# Altium schematic sheet ESP32_board.SchDoc(ESP32_board)
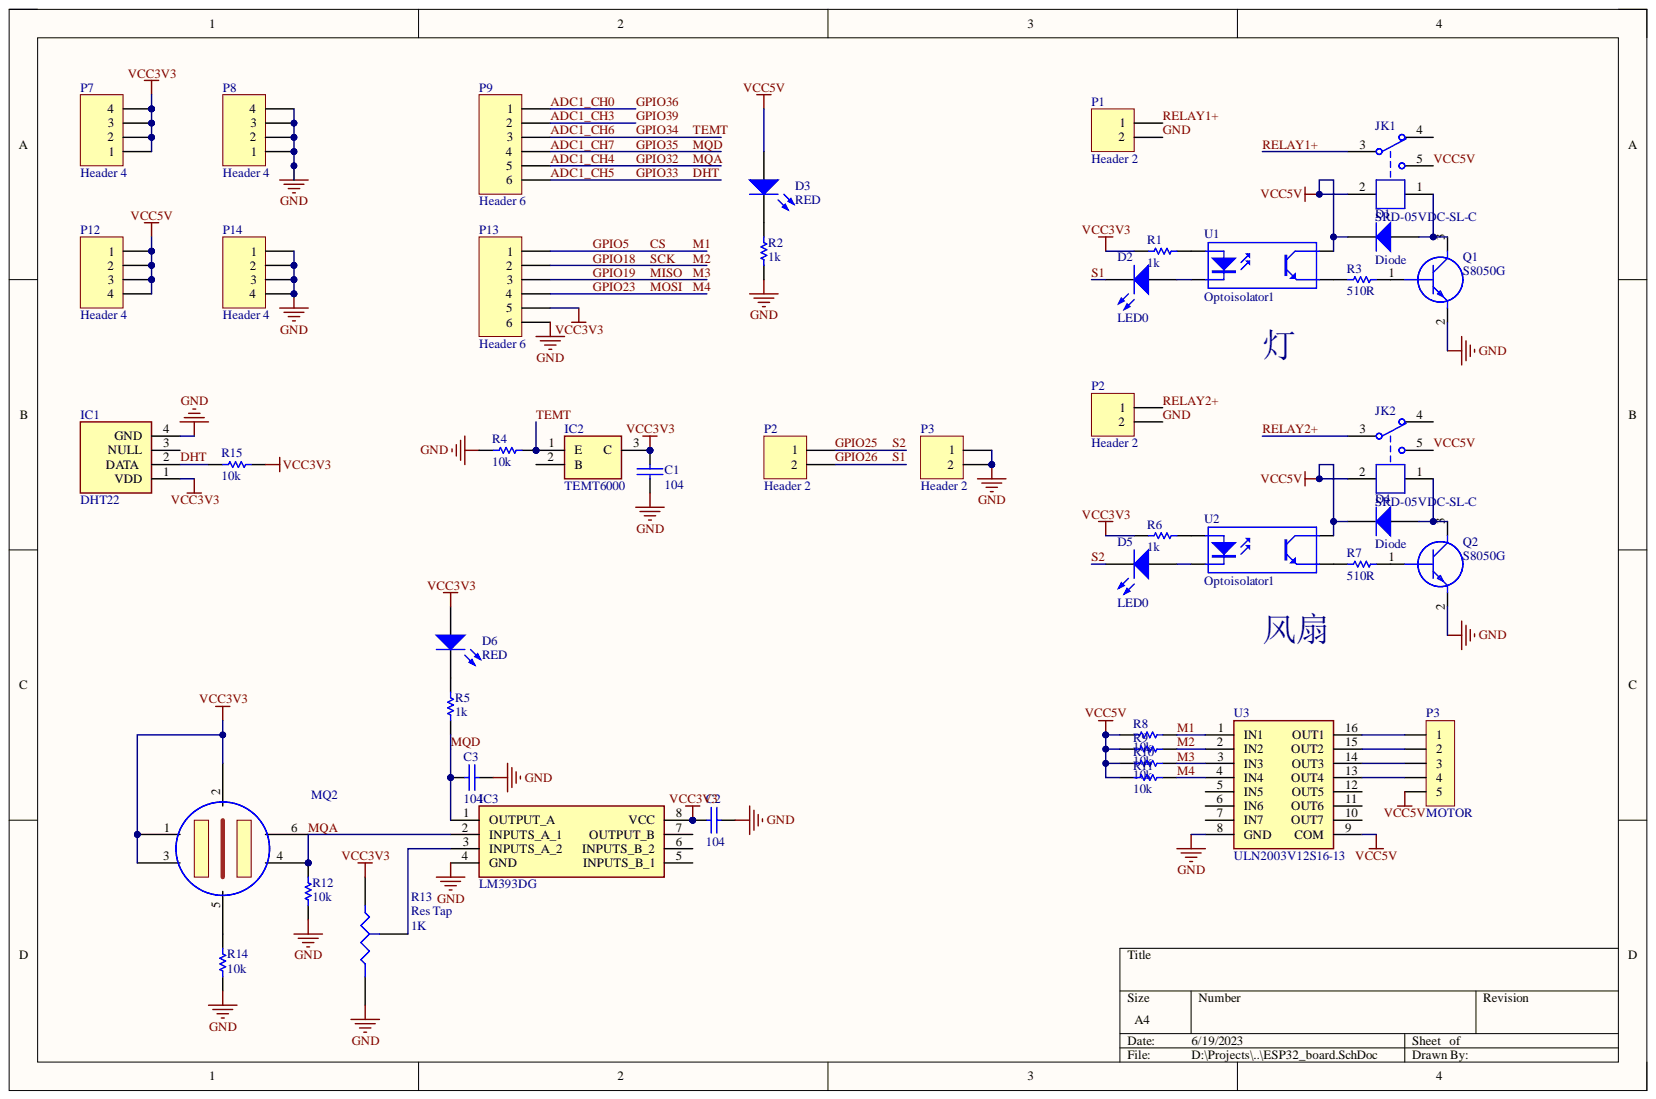
[diagram p0: ESP32_board.SchDoc]

## Parts
C1  104  [C0603_M]
C2  104  [C0603_M]
C3  104  [C0603_M]
D1  Diode  [MINI_MELF (LL34)]
D2  LED0  [LED0805_B]
D3  RED  [LED0805_B]
D4  Diode  [MINI_MELF (LL34)]
D5  LED0  [LED0805_B]
D6  RED  [LED0805_B]
IC1  DHT22  [DHT22]
IC2  TEMT6000  [TEMT6000]
IC3  LM393DG  [LM393]
JK1  SRD-05VDC-SL-C  [SRD-C_B]
JK2  SRD-05VDC-SL-C  [SRD-C_B]
MQ2  MQ  [QM-2]
P1  Header 2  [KF128-3.81-2P]
P2  Header 2  [HDR2.54-LI-2P]
P2  Header 2  [KF128-3.81-2P]
P3  Header 2  [HDR2.54-LI-2P]
P3  MOTOR  [XH2.54-LI-5P]
P7  Header 4  [HDR2.54-LI-4P]
P8  Header 4  [HDR2.54-LI-4P]
P9  Header 6  [HDR2.54-LI-6P]
P12  Header 4  [HDR2.54-LI-4P]
P13  Header 6  [HDR2.54-LI-6P]
P14  Header 4  [HDR2.54-LI-4P]
Q1  S8050G  [8050]
Q2  S8050G  [8050]
R1  value 1k  [R0603_M]  (hidden comment: Res1)
R2  value 1k  [R0603_M]  (hidden comment: Res1)
R3  value 510R  [R0603_M]  (hidden comment: Res1)
R4  value 10k  [R0603_M]  (hidden comment: Res1)
R5  value 1k  [R0603_M]  (hidden comment: Res1)
R6  value 1k  [R0603_M]  (hidden comment: Res1)
R7  value 510R  [R0603_M]  (hidden comment: Res1)
R8  value 10k  [R0603_M]  (hidden comment: Res1)
R9  value 10k  [R0603_M]  (hidden comment: Res1)
R10  value 10k  [R0603_M]  (hidden comment: Res1)
R11  value 10k  [R0603_M]  (hidden comment: Res1)
R12  value 10k  [R0603_M]  (hidden comment: Res1)
R13  Res Tap  value 1K  [RM065]
R14  value 10k  [R0603_M]  (hidden comment: Res1)
R15  value 10k  [R0603_M]  (hidden comment: Res1)
U1  Optoisolator1  [SOP4-W2.54_N]
U2  Optoisolator1  [SOP4-W2.54_N]
U3  ULN2003V12S16-13  [ULN2003]

## Nets
VCC3V3: C1.2 C2.2 D6.1 IC1.1(VDD) IC2.3 IC3.8(VCC) MQ2.1 MQ2.2 MQ2.3 P7.1 P7.2 P7.3 P7.4 P13.5 R1.2 R6.2 R13.1 R15.2
ADC1_CH0: P9.1
ADC1_CH3: P9.2
ADC1_CH4: IC3.2(INPUTS_A_1) MQ2.4 P9.5 R12.1
ADC1_CH5: IC1.2(DATA) P9.6 R15.1
ADC1_CH6: IC2.1 P9.3 R4.1
ADC1_CH7: C3.1 IC3.1(OUTPUT_A) P9.4 R5.1
CS: P13.1 R8.1 U3.1(IN1)
GND: C1.1 C2.1 C3.2 IC1.4(GND) IC3.4(GND) P1.2 P2.2 P3.1 P3.2 P8.1 P8.2 P8.3 P8.4 P13.6 P14.1 P14.2 P14.3 P14.4 Q1.2 Q2.2 R2.1 R4.2 R12.2 R13.2 R14.2 U3.8(GND)
GPIO18: P13.2 R9.1 U3.2(IN2)
GPIO19: P13.3 R10.1 U3.3(IN3)
GPIO23: P13.4 R11.1 U3.4(IN4)
GPIO25: D5.2 P2.1
GPIO26: D2.2 P2.2
RELAY1+: JK1.3 P1.1
RELAY2+: JK2.3 P2.1
VCC5V: D1.2 D3.1 D4.2 JK1.2 JK1.5 JK2.2 JK2.5 P3.5 P12.1 P12.2 P12.3 P12.4 R8.2 R9.2 R10.2 R11.2 U1.4 U2.4 U3.9(COM)
NetD1_1: D1.1 JK1.1 Q1.3
NetD2_1: D2.1 U1.2
NetD3_2: D3.2 R2.2
NetD4_1: D4.1 JK2.1 Q2.3
NetD5_1: D5.1 U2.2
NetD6_2: D6.2 R5.2
NetIC3_3: IC3.3(INPUTS_A_2) R13.3
NetMQ2_5: MQ2.5 R14.1
NetP3_1: P3.1 U3.16(OUT1)
NetP3_2: P3.2 U3.15(OUT2)
NetP3_3: P3.3 U3.14(OUT3)
NetP3_4: P3.4 U3.13(OUT4)
NetQ1_1: Q1.1 R3.1
NetQ2_1: Q2.1 R7.1
NetR1_1: R1.1 U1.1
NetR3_2: R3.2 U1.3
NetR6_1: R6.1 U2.1
NetR7_2: R7.2 U2.3

Not connected: IC1 3(NULL); IC2 2; IC3 5(INPUTS_B_1) 6(INPUTS_B_2) 7(OUTPUT_B); JK1 4; JK2 4; MQ2 6; U3 5(IN5) 6(IN6) 7(IN7) 10(OUT7) 11(OUT6) 12(OUT5)

## Library data of the parts
C1-C3 = CAP: Capacitor; Component Kind: Standard
D1 D4 = Diode: Default Diode; Code_JEDEC: DO-214-AB; Component Kind: Standard; LatestRevisionDate: 17-Jul-2002; LatestRevisionNote: Re-released for DXP Platform.; Library Name: Miscellaneous Devices.IntLib; PackageDescription: DO-214-AB/SMC; 2 C-Bend Leads; Body 7.9 x 5.9 mm, inc. leads (LxW); PackageReference: SMC; PackageVersion: Sep-1996; Published: 8-Jun-2000; Signal Integrity: Diode; Simulation: DIODE; Publisher: Altium Limited
D2 D3 D5 D6 = LED0: Typical INFRARED GaAs LED; Component Kind: Standard; LatestRevisionDate: 08-Jul-2005; LatestRevisionNote: Mech Layer 1 removed.; Library Name: Miscellaneous Devices.IntLib; PackageDescription: LED; 2 Leads; PackageReference: LED-0; Published: 8-Jun-2000; Simulation: LED0; Publisher: Altium Limited
IC1 = DHT22: Integrated Circuit; Manufacturer_Name: Aosong Electronics; Component Kind: Standard; Datasheet Link: https://datasheet4u.com/datasheet-parts/DHT22-datasheet.php?id=792211; Height: 25.1mm; Manufacturer_Part_Number: DHT22
IC2 = TEMT6000: Integrated Circuit; Manufacturer_Name: Vishay; Component Kind: Standard; Datasheet Link: https://www.vishay.com/docs/81579/temt6000.pdf; Height: 1.2mm; Manufacturer_Part_Number: TEMT6000; Mouser Part Number: N/A; Mouser Price/Stock: https://www.mouser.co.uk/ProductDetail/Vishay-Semiconductors/TEMT6000?qs=HsFHTXumnCR2I3GlkUjIMw%3D%3D
IC3 = LM393DG: Integrated Circuit; Manufacturer_Name: onsemi; Arrow Part Number: LM393DG; Arrow Price/Stock: https://www.arrow.com/en/products/lm393dg/on-semiconductor?region=nac; Component Kind: Standard; Datasheet Link: https://www.onsemi.com/pub/Collateral/LM393-D.PDF; Height: 1.75mm; Manufacturer_Part_Number: LM393DG; Mouser Part Number: 863-LM393DG; Mouser Price/Stock: https://www.mouser.co.uk/ProductDetail/onsemi/LM393DG?qs=2OtswVQKCOHzXsheSqC0ow%3D%3D
JK1 JK2 = SRD-05VDC-SL-C: 5V一组转换; BOM名称: 5V继电器; 联系卖家 免费查找封装: 封装库来自淘宝卖家ID：黄晶宝石; Component Kind: Standard; Library Name: AiClothes.SchLib
P1 P2 P2 P3 = Header 2: Header, 2-Pin; Component Kind: Standard; LatestRevisionDate: 17-Jul-2002; LatestRevisionNote: Re-released for DXP Platform.; Library Name: Miscellaneous Connectors.IntLib; Signal Integrity: Connector; Publisher: Altium Limited
P3 = Header 5: 5P接插件; 封装库来自淘宝卖家ID: 其他店铺购入均为盗版假冒封装库，请立即申请退款，本店现金奖励20~200元; 联系卖家，免费查找封装: 唯一出售店铺网址https://shop463601444.taobao.com; BOM名称: 5P接插件; Component Kind: Standard; Library Name: 5.5 - 2.54mm连接器(KF2EDGK_XH2.54_2.54排针排母).SchLib
P7 P8 P12 P14 = Header 4: Header, 4-Pin; Component Kind: Standard; LatestRevisionDate: 17-Jul-2002; LatestRevisionNote: Re-released for DXP Platform.; Library Name: Miscellaneous Connectors.IntLib; Signal Integrity: Connector; Publisher: Altium Limited
P9 P13 = Header 6: Header, 6-Pin; Component Kind: Standard; LatestRevisionDate: 17-Jul-2002; LatestRevisionNote: Re-released for DXP Platform.; Library Name: Miscellaneous Connectors.IntLib; Signal Integrity: Connector; Publisher: Altium Limited
Q1 Q2 = S8050G: Transistor BJT NPN; Manufacturer_Name: Galaxy; Component Kind: Standard; Height: 1.1mm; Manufacturer_Part_Number: S8050G
R1-R12 R14 R15 = Res1: Resistor; Component Kind: Standard; LatestRevisionDate: 17-Jul-2002; LatestRevisionNote: Re-released for DXP Platform.; Library Name: Miscellaneous Devices.IntLib; PackageDescription: Axial Device, Thru-Hole; 2 Leads; 0.3 in Pin Spacing; PackageReference: AXIAL-0.3; Published: 8-Jun-2000; Signal Integrity: Res; Simulation: RESISTOR; Publisher: Altium Limited
R13 = Res Tap: Tapped Resistor; Set Position: 0.5; Sim Note: Enter the Resistance value and the set point into parameter fields.; Component Kind: Standard; LatestRevisionDate: 17-Jul-2002; LatestRevisionNote: Re-released for DXP Platform.; Library Name: Miscellaneous Devices.IntLib; PackageDescription: Variable Resistor, Thru-Hole; 3 Leads; PackageReference: VR3; Published: 24-Mar-1999; Signal Integrity: Res Tap; Simulation: POT; Publisher: Altium Limited
U1 U2 = Optoisolator1: 4-Pin Phototransistor Optocoupler; Component Kind: Standard; DatasheetVersion: Nov-2003; LatestRevisionDate: 04-Mar-2005; LatestRevisionNote: Sch pins 3 & 4 Swapped.; Library Name: Miscellaneous Devices.IntLib; PackageReference: DIP-4; Published: 24-Mar-1999; Publisher: Altium Limited
U3 = ULN2003V12S16-13: Integrated Circuit; Manufacturer_Name: Diodes Inc.; Arrow Part Number: ULN2003V12S16-13; Arrow Price/Stock: https://www.arrow.com/en/products/uln2003v12s16-13/diodes-incorporated?region=nac; Component Kind: Standard; Datasheet Link: https://datasheet.datasheetarchive.com/originals/distributors/DKDS-29/579974.pdf; Height: 1.26mm; Manufacturer_Part_Number: ULN2003V12S16-13; Mouser Part Number: 621-ULN2003V12S16-13; Mouser Price/Stock: https://www.mouser.co.uk/ProductDetail/Diodes-Incorporated/ULN2003V12S16-13?qs=M5E3J2MuaV72wD7dJBLEZA%3D%3D
MQ2 = MQ: Component Kind: Standard; Library Name: ESP32_board.SchLib
